# Altium schematic sheet Power Board-Connector.SchDoc
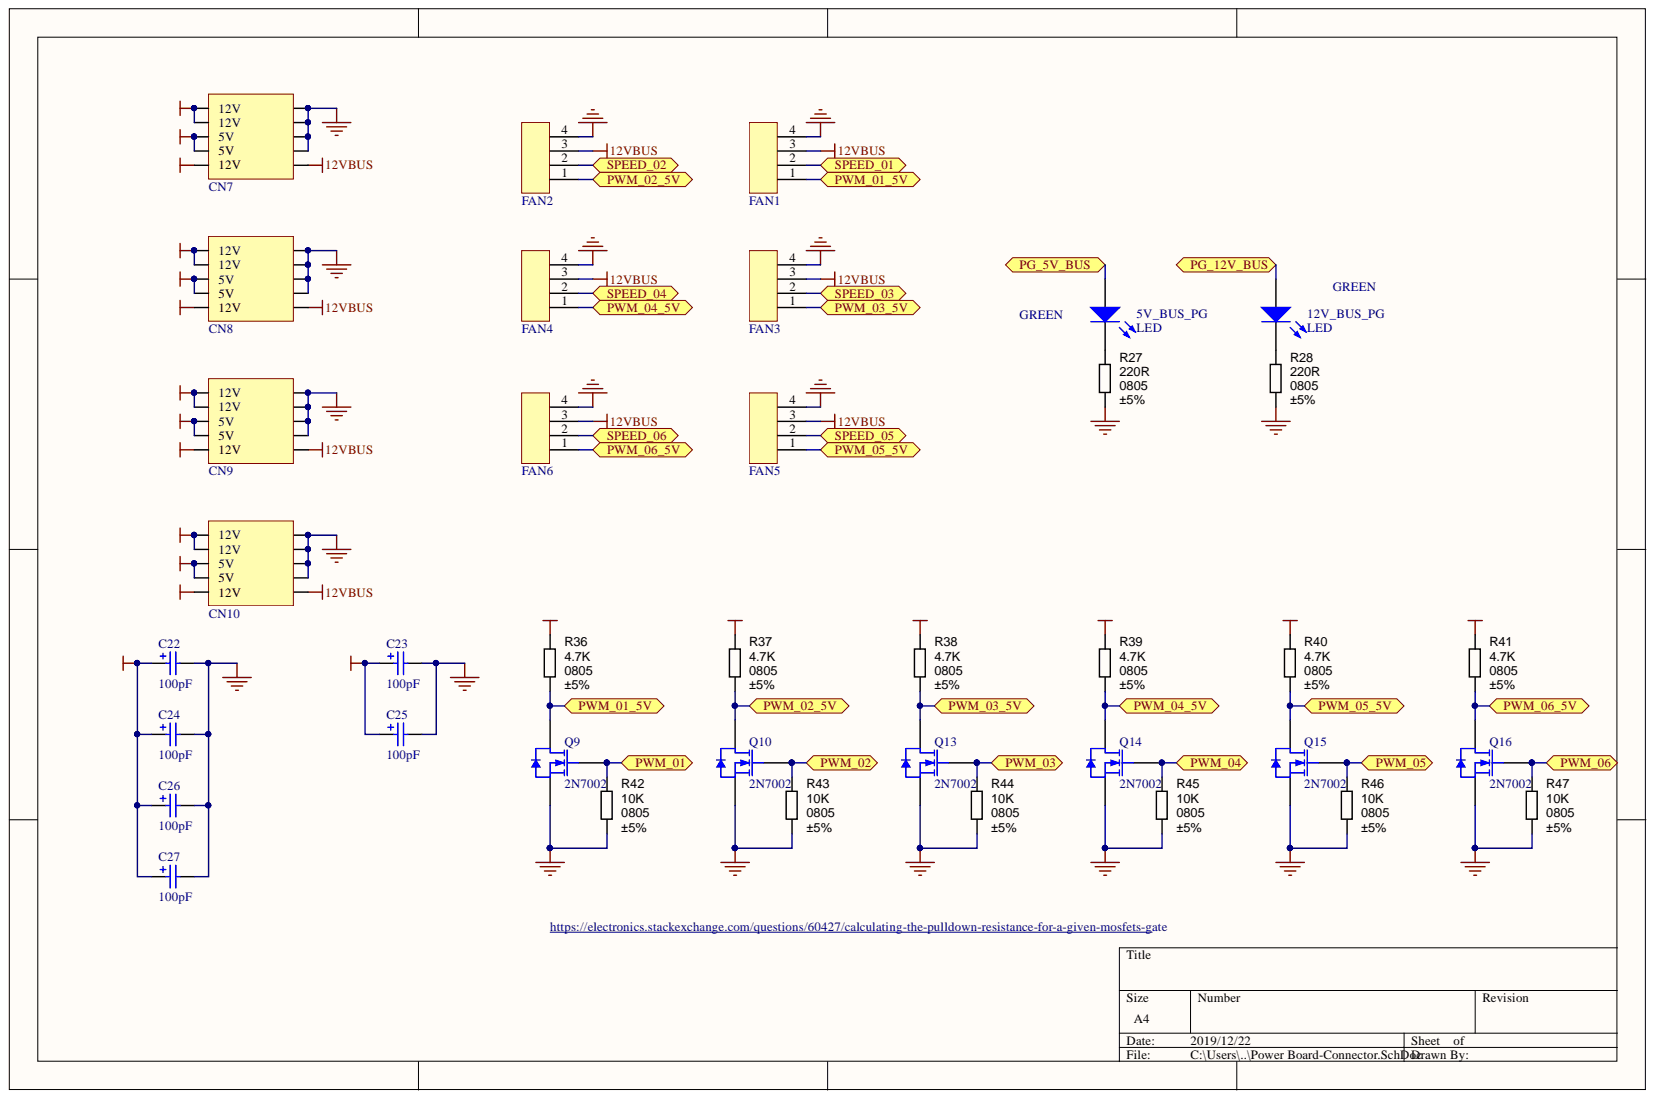
[diagram p0: Power Board-Connector.SchDoc]

## Parts
5V_BUS_PG  LED  [LED-0805]
12V_BUS_PG  LED  [LED-0805]
C22  value 100pF  [Radial 10x13mm]  (hidden comment: Cap Pol1 10mm)
C23  value 100pF  [Radial 10x13mm]  (hidden comment: Cap Pol1 10mm)
C24  value 100pF  [Radial 10x13mm]  (hidden comment: Cap Pol1 10mm)
C25  value 100pF  [Radial 10x13mm]  (hidden comment: Cap Pol1 10mm)
C26  value 100pF  [Radial 10x13mm]  (hidden comment: Cap Pol1 10mm)
C27  value 100pF  [Radial 10x13mm]  (hidden comment: Cap Pol1 10mm)
CN7  DL180G6_SAS  [5566 4.2mm Pitch 10.2]  (value not drawn)
CN8  DL180G6_SAS  [5566 4.2mm Pitch 10.2]  (value not drawn)
CN9  DL180G6_SAS  [5566 4.2mm Pitch 10.2]  (value not drawn)
CN10  DL180G6_SAS  [5566 4.2mm Pitch 10.2]  (value not drawn)
FAN1  04 PIN Molex 2.54 mm 22-27 KK 6410 Series  [04 PIN 02272041]  (value not drawn)
FAN2  04 PIN Molex 2.54 mm 22-27 KK 6410 Series  [04 PIN 02272041]  (value not drawn)
FAN3  04 PIN Molex 2.54 mm 22-27 KK 6410 Series  [04 PIN 02272041]  (value not drawn)
FAN4  04 PIN Molex 2.54 mm 22-27 KK 6410 Series  [04 PIN 02272041]  (value not drawn)
FAN5  04 PIN Molex 2.54 mm 22-27 KK 6410 Series  [04 PIN 02272041]  (value not drawn)
FAN6  04 PIN Molex 2.54 mm 22-27 KK 6410 Series  [04 PIN 02272041]  (value not drawn)
Q9  2N7002  [SOT23]
Q10  2N7002  [SOT23]
Q13  2N7002  [SOT23]
Q14  2N7002  [SOT23]
Q15  2N7002  [SOT23]
Q16  2N7002  [SOT23]
R27  value 220R  Package / Case: 0805  Tolerance: ±5%  [RCS0805-e3]  (hidden comment: RES_220R_0805_5%)
R28  value 220R  Package / Case: 0805  Tolerance: ±5%  [RCS0805-e3]  (hidden comment: RES_220R_0805_5%)
R36  value 4.7K  Package / Case: 0805  Tolerance: ±5%  [RCS0805-e3]  (hidden comment: RES_4.7K_0805_5%)
R37  value 4.7K  Package / Case: 0805  Tolerance: ±5%  [RCS0805-e3]  (hidden comment: RES_4.7K_0805_5%)
R38  value 4.7K  Package / Case: 0805  Tolerance: ±5%  [RCS0805-e3]  (hidden comment: RES_4.7K_0805_5%)
R39  value 4.7K  Package / Case: 0805  Tolerance: ±5%  [RCS0805-e3]  (hidden comment: RES_4.7K_0805_5%)
R40  value 4.7K  Package / Case: 0805  Tolerance: ±5%  [RCS0805-e3]  (hidden comment: RES_4.7K_0805_5%)
R41  value 4.7K  Package / Case: 0805  Tolerance: ±5%  [RCS0805-e3]  (hidden comment: RES_4.7K_0805_5%)
R42  value 10K  Package / Case: 0805  Tolerance: ±5%  [RCS0805-e3]  (hidden comment: RES_10K_0805_5%)
R43  value 10K  Package / Case: 0805  Tolerance: ±5%  [RCS0805-e3]  (hidden comment: RES_10K_0805_5%)
R44  value 10K  Package / Case: 0805  Tolerance: ±5%  [RCS0805-e3]  (hidden comment: RES_10K_0805_5%)
R45  value 10K  Package / Case: 0805  Tolerance: ±5%  [RCS0805-e3]  (hidden comment: RES_10K_0805_5%)
R46  value 10K  Package / Case: 0805  Tolerance: ±5%  [RCS0805-e3]  (hidden comment: RES_10K_0805_5%)
R47  value 10K  Package / Case: 0805  Tolerance: ±5%  [RCS0805-e3]  (hidden comment: RES_10K_0805_5%)

## Ports
PG_5V_BUS, PG_12V_BUS, PWM_01, PWM_01_5V, PWM_02, PWM_02_5V, PWM_03, PWM_03_5V, PWM_04, PWM_04_5V, PWM_05, PWM_05_5V, PWM_06, PWM_06_5V, SPEED_01, SPEED_02, SPEED_03, SPEED_04, SPEED_05, SPEED_06

## Nets
5VBUS: C23.1 C25.1 CN7.8(5V) CN7.9(5V) CN8.8(5V) CN8.9(5V) CN9.8(5V) CN9.9(5V) CN10.8(5V) CN10.9(5V) R36.1 R37.1 R38.1 R39.1 R40.1 R41.1
12VBUS: C22.1 C24.1 C26.1 C27.1 CN7.5 CN7.6(12V) CN7.7(12V) CN7.10(12V) CN8.5 CN8.6(12V) CN8.7(12V) CN8.10(12V) CN9.5 CN9.6(12V) CN9.7(12V) CN9.10(12V) CN10.5 CN10.6(12V) CN10.7(12V) CN10.10(12V) FAN1.3 FAN2.3 FAN3.3 FAN4.3 FAN5.3 FAN6.3
GND: C22.2 C23.2 C24.2 C25.2 C26.2 C27.2 CN7.1 CN7.2 CN7.3 CN7.4 CN8.1 CN8.2 CN8.3 CN8.4 CN9.1 CN9.2 CN9.3 CN9.4 CN10.1 CN10.2 CN10.3 CN10.4 FAN1.4 FAN2.4 FAN3.4 FAN4.4 FAN5.4 FAN6.4 Q9.2 Q10.2 Q13.2 Q14.2 Q15.2 Q16.2 R27.2 R28.2 R42.2 R43.2 R44.2 R45.2 R46.2 R47.2
PG_5V_BUS: 5V_BUS_PG.1 ; port PG_5V_BUS
PG_12V_BUS: 12V_BUS_PG.1 ; port PG_12V_BUS
PWM_01: Q9.1 R42.1 ; port PWM_01
PWM_01_5V: FAN1.1 Q9.3 R36.2 ; port PWM_01_5V
PWM_02: Q10.1 R43.1 ; port PWM_02
PWM_02_5V: FAN2.1 Q10.3 R37.2 ; port PWM_02_5V
PWM_03: Q13.1 R44.1 ; port PWM_03
PWM_03_5V: FAN3.1 Q13.3 R38.2 ; port PWM_03_5V
PWM_04: Q14.1 R45.1 ; port PWM_04
PWM_04_5V: FAN4.1 Q14.3 R39.2 ; port PWM_04_5V
PWM_05: Q15.1 R46.1 ; port PWM_05
PWM_05_5V: FAN5.1 Q15.3 R40.2 ; port PWM_05_5V
PWM_06: Q16.1 R47.1 ; port PWM_06
PWM_06_5V: FAN6.1 Q16.3 R41.2 ; port PWM_06_5V
SPEED_01: FAN1.2 ; port SPEED_01
SPEED_02: FAN2.2 ; port SPEED_02
SPEED_03: FAN3.2 ; port SPEED_03
SPEED_04: FAN4.2 ; port SPEED_04
SPEED_05: FAN5.2 ; port SPEED_05
SPEED_06: FAN6.2 ; port SPEED_06
Net5V_BUS_PG_2: 5V_BUS_PG.2 R27.1
Net12V_BUS_PG_2: 12V_BUS_PG.2 R28.1

## Library data of the parts
5V_BUS_PG 12V_BUS_PG = LED: Typical INFRARED GaAs LED; Component Kind: Standard; LatestRevisionDate: 08-Jul-2005; LatestRevisionNote: Mech Layer 1 removed.; PackageDescription: LED; 2 Leads; PackageReference: LED-0; Published: 8-Jun-2000; Simulation: LED0; Library Name: roger225_Altium_Library.IntLib; Publisher: Altium Limited
C22-C27 = Cap Pol1 10mm: Polarized Capacitor (Radial); Component Kind: Standard; LatestRevisionDate: 17-Jul-2002; LatestRevisionNote: Re-released for DXP Platform.; Library Name: Capacitors DIP.IntLib; PackageDescription: Polarized Capacitor (Radial); 2 Leads; PackageReference: RB7.6-15; Published: 8-Jun-2000; Signal Integrity: Cap Pol; Simulation: CAP; Publisher: Altium Limited
Q9 Q10 Q13-Q16 = 2N7002: N-channel Enhancement Mode Field-effect Transistor; Component Kind: Standard; ComponentLink1Description: Manufacturer Link; ComponentLink1URL: http://www.semiconductors.philips.com/; ComponentLink2Description: Datasheet; ComponentLink2URL: http://www.semiconductors.philips.com/acrobat/datasheets/2N7002_4.pdf; DatasheetVersion: 27-Jul-2000; PackageDescription: SOT-23; 3 Leads; Body 3.0 x 2.5 mm, inc. leads (LxW max); PackageReference: SOT23; PackageVersion: May-1999; Published: 17-Sep-2003; Simulation: 2N7002; Library Name: roger225_Altium_Library.IntLib; Publisher: Altium Limited
R27 R28 = RES_220R_0805_5%: Resistor SMD chip 220 Ohm 0.125W 5% 0805; Ratings: 1/8W; SCDType: spec; Component Kind: Standard; Library Name: roger225_Altium_Library.IntLib
R36-R41 = RES_4.7K_0805_5%: Resistor SMD chip 4.7k Ohm 0.125W 5% 0805; Manufacturer 1: Vishay/Dale; Manufacturer Part Number 1: CRCW08054K70JNEA; Ratings: 1/8W; SCDType: spec; Component Kind: Standard; Library Name: roger225_Altium_Library.IntLib
R42-R47 = RES_10K_0805_5%: Resistor SMD chip 10k Ohm 0.125W 5% 0805; Manufacturer 1: Vishay/Dale; Manufacturer Part Number 1: CRCW080510K0JNEA; Ratings: 1/8W; SCDType: spec; Component Kind: Standard; Library Name: roger225_Altium_Library.IntLib
CN7-CN10 = DL180G6_SAS: Component Kind: Standard; Description: DL180G6_SAS; Library Name: ATX Connector.IntLib
FAN1-FAN6 = 04 PIN Molex 2.54 mm 22-27 KK 6410 Series: Component Kind: Standard; Description: 04 PIN Molex 2.54 mm 22-27 KK 6410 Series; Library Name: Molex 2.54 mm 22-27 KK 6410 Series.IntLib
